# Altium schematic sheet Interface.SchDoc(Interface)
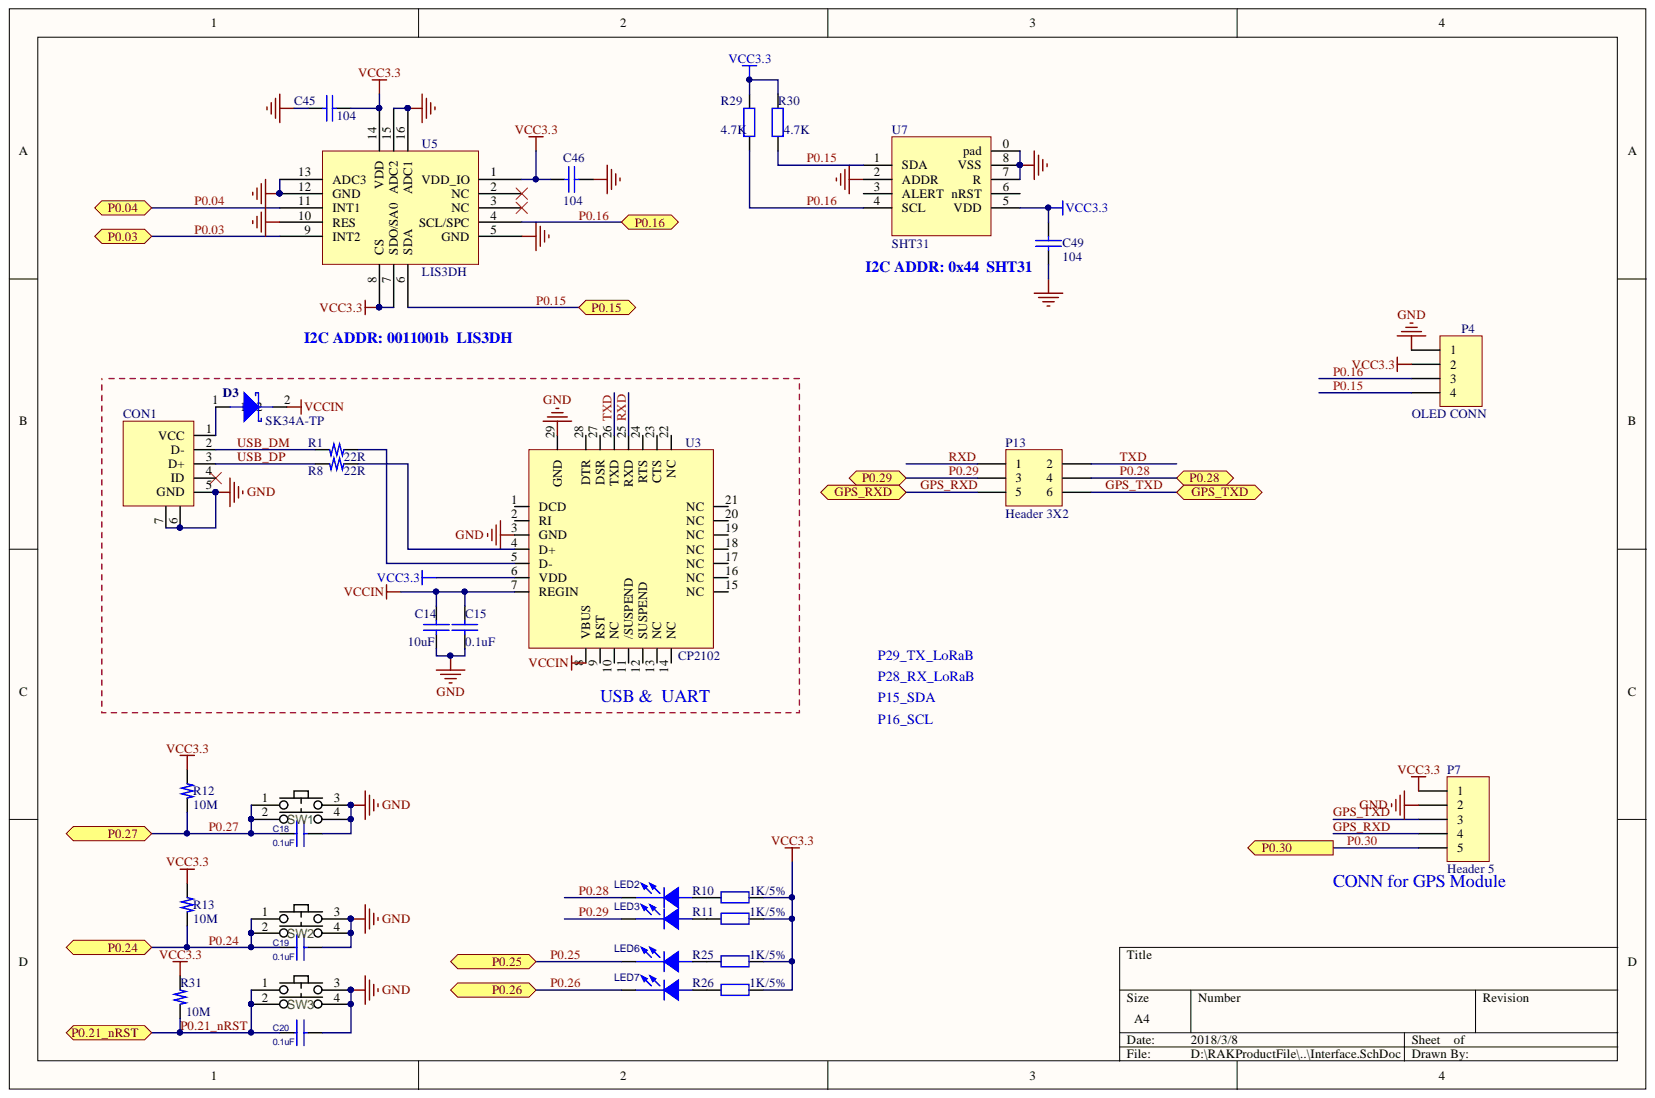
[diagram p0: Interface.SchDoc]

## Parts
C14  10uF  [CAPC1608X06L]
C15  0.1uF  [CAPC1005X04L]
C18  0.1uF  [CAPC1005X04L]
C19  0.1uF  [CAPC1005X04L]
C20  0.1uF  [CAPC1005X04L]
C45  104  [CAPC1005X04L]
C46  104  [CAPC1005X04L]
C49  104  [CAPC1005X04L]
CON1  MICRO USB  [Micro USB通用]  (value not drawn)
D3  value SK34A-TP  [sod-sk34a]  (hidden comment: DIODE SCHOTTKY)
LED2  (no value)  [LED0603]
LED3  (no value)  [LED0603]
LED6  (no value)  [LED0603]
LED7  (no value)  [LED0603]
P4  OLED CONN  [OLED_MODULE]
P7  Header 5  [HDR1X5]
P13  Header 3X2  [HDR2X3]
R1  22R  [CAPC1608X06L]
R8  22R  [CAPC1608X06L]
R10  1K/5%  [CAPC1005X04L]
R11  1K/5%  [CAPC1005X04L]
R12  10M  [CAPC1005X04L]
R13  10M  [CAPC1005X04L]
R25  1K/5%  [CAPC1005X04L]
R26  1K/5%  [CAPC1005X04L]
R29  4.7K  [CAPC1005X04L]
R30  4.7K  [CAPC1005X04L]
R31  10M  [CAPC1005X04L]
SW1  KEY3  [YH/4脚SMD轻触开关_3D_2]  (value not drawn)
SW2  KEY3  [YH/4脚SMD轻触开关_3D_2]  (value not drawn)
SW3  KEY3  [YH/4脚SMD轻触开关_3D_2]  (value not drawn)
U3  CP2102  [QFN50P500X500X80-28N]
U5  LIS3DH  [LGA-16]
U7  SHT31  [SHT3x-DIS]

## Ports
GPS_RXD, GPS_TXD, P0.03, P0.04, P0.15, P0.16, P0.21_NRST, P0.24, P0.25, P0.26, P0.27, P0.28, P0.29, P0.30

## Nets
GND: C14.2 C15.1 C18.2 C19.2 C20.2 C45.1 C46.1 C49.2 CON1.5(GND) CON1.6 CON1.7 P4.1 P7.2 SW1.3 SW1.4 SW2.3 SW2.4 SW3.3 SW3.4 U3.3(GND) U3.29(GND) U5.5(GND) U5.10(RES) U5.12(GND) U5.13(ADC3) U5.15(ADC2) U5.16(ADC1) U7.0(pad) U7.2(ADDR) U7.7(R) U7.8(VSS)
VCC3.3: C45.2 C46.2 C49.1 P4.2 P7.1 R10.2 R11.2 R12.1 R13.1 R25.2 R26.2 R29.2 R30.2 R31.1 U3.6(VDD) U5.1(VDD_IO) U5.7(SDO/SA0) U5.8(CS) U5.14(VDD) U7.5(VDD)
VCCIN: C14.1 C15.2 D3.2 U3.7(REGIN) U3.8(VBUS)
GPS_RXD: P7.4 P13.5 ; port GPS_RXD
GPS_TXD: P7.3 P13.6 ; port GPS_TXD
P0.03: U5.9(INT2) ; port P0.03
P0.04: U5.11(INT1) ; port P0.04
P0.15: P4.4 R30.1 U5.6(SDA) U7.1(SDA) ; port P0.15
P0.16: P4.3 R29.1 U5.4(SCL/SPC) U7.4(SCL) ; port P0.16
P0.21_NRST: C20.1 R31.2 SW3.1 SW3.2 ; port P0.21_NRST
P0.24: C19.1 R13.2 SW2.1 SW2.2 ; port P0.24
P0.25: LED6.2 ; port P0.25
P0.26: LED7.2 ; port P0.26
P0.27: C18.1 R12.2 SW1.1 SW1.2 ; port P0.27
P0.28: LED2.2 P13.4 ; port P0.28
P0.29: LED3.2 P13.3 ; port P0.29
P0.30: P7.5 ; port P0.30
RXD: P13.1 U3.25(RXD)
TXD: P13.2 U3.26(TXD)
USB_DM: CON1.2(D-) R1.2
USB_DP: CON1.3(D+) R8.2
NetCON1_1: CON1.1(VCC) D3.1
NetLED2_1: LED2.1 R10.1
NetLED3_1: LED3.1 R11.1
NetLED6_1: LED6.1 R25.1
NetLED7_1: LED7.1 R26.1
NetR1_1: R1.1 U3.5(D-)
NetR8_1: R8.1 U3.4(D+)

Not connected: CON1 4(ID); U3 1(DCD) 2(RI) 9(RST) 10(NC) 11(/SUSPEND) 12(SUSPEND) 13(NC) 14(NC) 15(NC) 16(NC) 17(NC) 18(NC) 19(NC) 20(NC) 21(NC) 22(NC) 23(CTS) 24(RTS) 27(DSR) 28(DTR); U5 2(NC) 3(NC); U7 3(ALERT) 6(nRST)

## Library data of the parts
C14 C15 C45 C46 C49 = CAP: Capacitor; Component Kind: Standard
  C14 C15: Library Name: 电阻容感类.SchLib
C18-C20 = C: Component Kind: Standard; Package: 0603; Library Name: NUC950_EVB_V13.SCHLIB
D3 = 1.04.07.0021: SK34A-TP,DO-214AC,MCC,RoHS; Database Table Name: VErpDataInfo; 名称: 二极管; 设备封装名称: DO-214AC_SK34A; 贴片和直插: SMT; Component Kind: Standard; ComponentLink1Description: ErpDataInfo; ComponentLink1URL: http://erp.zlgmcu.com/ErpExt/ErpData/ListMateriel.aspx?pkey=1.04.07.0021; Library Name: ErpMaterialData.DbLib; RoHS: RoHS; 厂商: MCC; 型号: SK34A-TP; 封装: DO-214AC; 物料代码: 1.04.07.0021
LED2 LED3 LED6 LED7 = LED: Component Kind: Standard; Library Name: NUC950_EVB_V13.SCHLIB
P4 = Header 4: Header, 4-Pin; Component Kind: Standard; LatestRevisionDate: 17-Jul-2002; LatestRevisionNote: Re-released for DXP Platform.; Library Name: Miscellaneous Connectors.IntLib; Signal Integrity: Connector; Publisher: Altium Limited
P7 = Header 5: Header, 5-Pin; Component Kind: Standard; LatestRevisionDate: 17-Jul-2002; LatestRevisionNote: Re-released for DXP Platform.; Library Name: Miscellaneous Connectors.IntLib; Signal Integrity: Connector; Publisher: Altium Limited
P13 = Header 3X2: Header, 3-Pin, Dual row; Component Kind: Standard; LatestRevisionDate: 17-Jul-2002; LatestRevisionNote: Re-released for DXP Platform.; Library Name: Miscellaneous Connectors.IntLib; Signal Integrity: Connector; Publisher: Altium Limited
R1 R8 R12 R13 R31 = 电阻: Component Kind: Standard
R10 R11 R25 R26 R29 R30 = Res2: Resistor; Value: 10K; Component Kind: Standard; LatestRevisionDate: 17-Jul-2002; LatestRevisionNote: Re-released for DXP Platform.; PackageReference: AXIAL-0.4; Published: 8-Jun-2000; Publisher: Altium Limited
SW1-SW3 = KEY1: Component Kind: Standard
CON1 = MICRO USB: Component Kind: Standard; Library Name: 接口类.SchLib
U3 = CP2102: Component Kind: Standard; Library Name: Schlib1.SchLib
U5 = LIS3DH: Component Kind: Standard; Library Name: Schlib1.SchLib
U7 = SHT31: Component Kind: Standard; Library Name: Schlib1.SchLib
